# Altium schematic sheet ETH.SchDoc
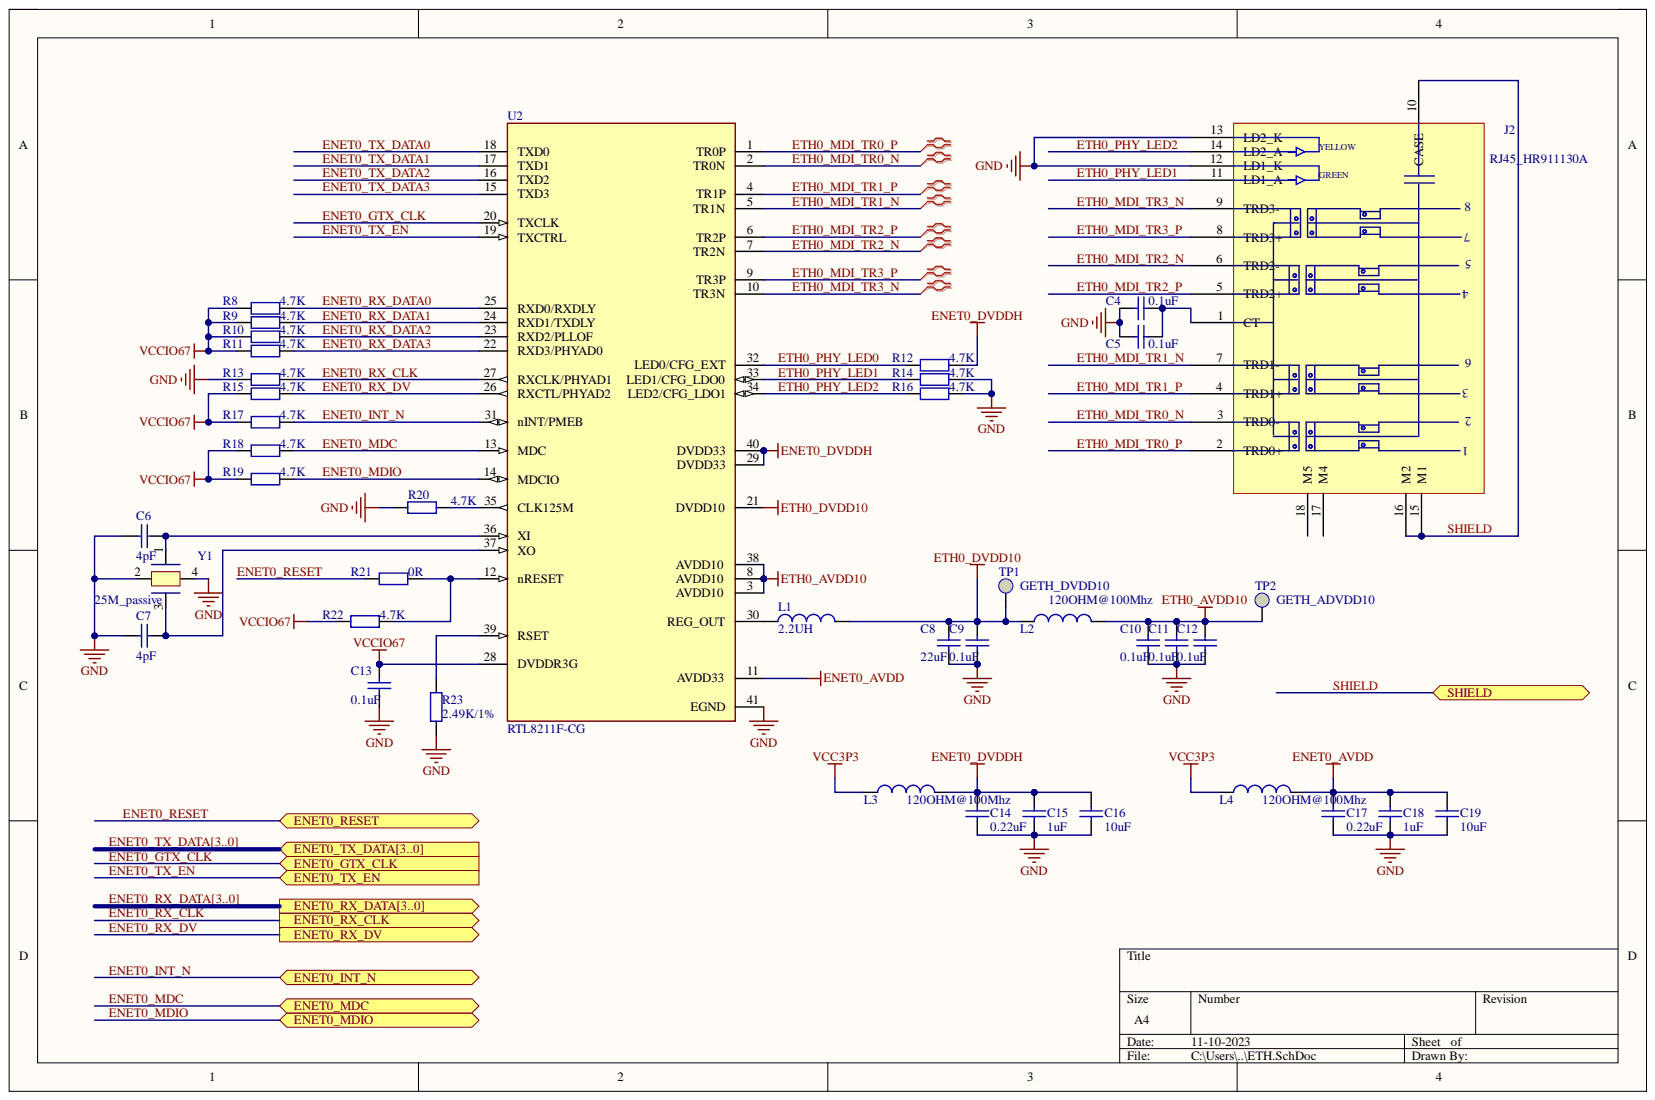
[diagram p0: ETH.SchDoc]

## Parts
C4  0.1uF  [C0402]
C5  0.1uF  [C0402]
C6  4pF  [C0402]
C7  4pF  [C0402]
C8  22uF  [C1206]
C9  0.1uF  [C0402]
C10  0.1uF  [C0402]
C11  0.1uF  [C0402]
C12  0.1uF  [C0402]
C13  0.1uF  [C0402]
C14  0.22uF  [C0402]
C15  1uF  [C0603]
C16  10uF  [C0805]
C17  0.22uF  [C0402]
C18  1uF  [C0603]
C19  10uF  [C0805]
J2  RJ45_HR911130A  [RJ45_HR911130C]
L1  2.2UH  [L0603]
L2  120OHM@100Mhz  [L0603]
L3  120OHM@100Mhz  [L0603]
L4  120OHM@100Mhz  [L0603]
R8  4.7K  [R0402]
R9  4.7K  [R0402]
R10  4.7K  [R0402]
R11  4.7K  [R0402]
R12  4.7K  [R0402]
R13  4.7K  [R0402]
R14  4.7K  [R0402]
R15  4.7K  [R0402]
R16  4.7K  [R0402]
R17  4.7K  [R0402]
R18  4.7K  [R0402]
R19  4.7K  [R0402]
R20  4.7K  [R0402]
R21  0R  [R0402]
R22  4.7K  [R0402]
R23  2.49K/1%  [R0402]
TP1  GETH_DVDD10  [tp32]
TP2  GETH_ADVDD10  [tp32]
U2  RTL8211F-CG  [qfn-40_l5_0-w5_0-p0_4-bl-ep]
Y1  25M_passive  [X4-3225]

## Ports
ENET0_GTX_CLK, ENET0_INT_N, ENET0_MDC, ENET0_MDIO, ENET0_RESET, ENET0_RX_CLK, ENET0_RX_DATA0, ENET0_RX_DATA1, ENET0_RX_DATA2, ENET0_RX_DATA3, ENET0_RX_DATA[3..0], ENET0_RX_DV, ENET0_TX_DATA0, ENET0_TX_DATA1, ENET0_TX_DATA2, ENET0_TX_DATA3, ENET0_TX_DATA[3..0], ENET0_TX_EN, SHIELD

## Nets
ENET0_AVDD: C17.2 C18.2 C19.2 L4.2 U2.11(AVDD33)
ENET0_DVDDH: C14.2 C15.2 C16.2 L3.2 R12.2 U2.29(DVDD33) U2.40(DVDD33)
ETH0_AVDD10: C10.1 C11.1 C12.1 L2.2 TP2.1 U2.3(AVDD10) U2.8(AVDD10) U2.38(AVDD10)
ETH0_DVDD10: C8.1 C9.1 L1.2 L2.1 TP1.1 U2.21(DVDD10)
GND: C4.1 C5.1 C6.1 C7.1 C8.2 C9.2 C10.2 C11.2 C12.2 C13.2 C14.1 C15.1 C16.1 C17.1 C18.1 C19.1 J2.12(LD1_K) J2.13(LD2_K) R13.1 R14.2 R16.2 R20.2 R23.1 U2.41(EGND) Y1.2 Y1.4
VCC3P3: L3.1 L4.1
VCCIO67: C13.1 R8.1 R9.1 R10.1 R11.1 R15.1 R17.1 R18.1 R19.1 R22.1 U2.28(DVDDR3G)
ENET0_GTX_CLK: U2.20(TXCLK) ; port ENET0_GTX_CLK
ENET0_INT_N: R17.2 U2.31(nINT/PMEB) ; port ENET0_INT_N
ENET0_MDC: R18.2 U2.13(MDC) ; port ENET0_MDC
ENET0_MDIO: R19.2 U2.14(MDCIO) ; port ENET0_MDIO
ENET0_RESET: R21.1 ; port ENET0_RESET
ENET0_RX_CLK: R13.2 U2.27(RXCLK/PHYAD1) ; port ENET0_RX_CLK
ENET0_RX_DATA0: R8.2 U2.25(RXD0/RXDLY) ; port ENET0_RX_DATA[3..0]  [also labelled ENET0_RX_DATA]
ENET0_RX_DATA1: R9.2 U2.24(RXD1/TXDLY) ; port ENET0_RX_DATA[3..0]  [also labelled ENET0_RX_DATA]
ENET0_RX_DATA2: R10.2 U2.23(RXD2/PLLOF) ; port ENET0_RX_DATA[3..0]  [also labelled ENET0_RX_DATA]
ENET0_RX_DATA3: R11.2 U2.22(RXD3/PHYAD0) ; port ENET0_RX_DATA[3..0]  [also labelled ENET0_RX_DATA]
ENET0_RX_DV: R15.2 U2.26(RXCTL/PHYAD2) ; port ENET0_RX_DV
ENET0_TX_DATA0: U2.18(TXD0) ; port ENET0_TX_DATA[3..0]  [also labelled ENET0_TX_DATA]
ENET0_TX_DATA1: U2.17(TXD1) ; port ENET0_TX_DATA[3..0]  [also labelled ENET0_TX_DATA]
ENET0_TX_DATA2: U2.16(TXD2) ; port ENET0_TX_DATA[3..0]  [also labelled ENET0_TX_DATA]
ENET0_TX_DATA3: U2.15(TXD3) ; port ENET0_TX_DATA[3..0]  [also labelled ENET0_TX_DATA]
ENET0_TX_EN: U2.19(TXCTRL) ; port ENET0_TX_EN
ETH0_MDI_TR0_N: J2.3(TRD0-) U2.2(TR0N)
ETH0_MDI_TR0_P: J2.2(TRD0+) U2.1(TR0P)
ETH0_MDI_TR1_N: J2.7(TRD1-) U2.5(TR1N)
ETH0_MDI_TR1_P: J2.4(TRD1+) U2.4(TR1P)
ETH0_MDI_TR2_N: J2.6(TRD2-) U2.7(TR2N)
ETH0_MDI_TR2_P: J2.5(TRD2+) U2.6(TR2P)
ETH0_MDI_TR3_N: J2.9(TRD3-) U2.10(TR3N)
ETH0_MDI_TR3_P: J2.8(TRD3+) U2.9(TR3P)
ETH0_PHY_LED0: R12.1 U2.32(LED0/CFG_EXT)
ETH0_PHY_LED1: J2.11(LD1_A) R14.1 U2.33(LED1/CFG_LDO0)
ETH0_PHY_LED2: J2.14(LD2_A) R16.1 U2.34(LED2/CFG_LDO1)
SHIELD: J2.10(CASE) J2.15(M1) J2.16(M2) ; port SHIELD
NetC4_2: C4.2 C5.2 J2.1(CT)
NetC6_2: C6.2 U2.36(XI) Y1.1
NetC7_2: C7.2 U2.37(XO) Y1.3
NetL1_1: L1.1 U2.30(REG_OUT)
NetR20_1: R20.1 U2.35(CLK125M)
NetR21_2: R21.2 R22.2 U2.12(nRESET)
NetR23_2: R23.2 U2.39(RSET)

Not connected: J2 17(M4) 18(M5)

## Library data of the parts
C4-C19 = Cap: Capacitor; Component Kind: Standard; LatestRevisionDate: 17-Jul-2002; LatestRevisionNote: Re-released for DXP Platform.; PackageReference: RAD-0.3; Published: 8-Jun-2000; Signal Integrity: Cap; Simulation: CAP; Publisher: Altium Limited
  C4 C5 C9-C13: Value: 0.1uF
  C6 C7: Library Name: Miscellaneous Devices.IntLib; PackageDescription: Radial Cap, Thru-Hole; 2 Leads; 0.3 in Pin Spacing; Value: 4pF
  C8: Value: 22uF
  C14 C17: Library Name: Miscellaneous Devices.IntLib; PackageDescription: Radial Cap, Thru-Hole; 2 Leads; 0.3 in Pin Spacing; Value: 0.22uF
  C15 C18: Library Name: Miscellaneous Devices.IntLib; PackageDescription: Radial Cap, Thru-Hole; 2 Leads; 0.3 in Pin Spacing; Value: 1uF
  C16 C19: Library Name: Miscellaneous Devices.IntLib; PackageDescription: Radial Cap, Thru-Hole; 2 Leads; 0.3 in Pin Spacing; Value: 10uF
  C4 C5 C8-C13: Library Name: 元件库封装.pcblib
J2 = GE_RJ45_2: Component Kind: Standard; Library Name: Schlib1.SchLib; Value: RJ45_HR911130A
L1 = Inductor: Code_IEC: 1005; Code_JEITA: 0402; Component Kind: Standard; Description: Inductor; LatestRevisionDate: 29-May-2009; LatestRevisionNote: IPC-7351 Footprint Added.; Library Name: Miscellaneous Devices.IntLib; PackageDescription: Chip Inductor; PackageReference: 0402-A; Published: 8-Jun-2000; Value: 2.2UH; Publisher: Altium Limited
L2-L4 = Inductor: Code_IEC: 1005; Value: 100ohm/1.2A; Code_JEITA: 0402; Component Kind: Standard; Description: Inductor; LatestRevisionDate: 29-May-2009; LatestRevisionNote: IPC-7351 Footprint Added.; Library Name: Miscellaneous Devices.IntLib; PackageDescription: Chip Inductor; PackageReference: 0402-A; Published: 8-Jun-2000; Publisher: Altium Limited
R8-R23 = Res2: Resistor; Component Kind: Standard; LatestRevisionDate: 17-Jul-2002; LatestRevisionNote: Re-released for DXP Platform.; PackageReference: AXIAL-0.4; Published: 8-Jun-2000; Library Name: 元件封装库.pcblib; Publisher: Altium Limited
  R8-R20 R22: Value: 4.7K
  R21: Value: 0R
  R23: Value: 2.49K/1%
TP1 TP2 = TestPoint: Component Kind: Standard; Library Name: USB302.Lib
U2 = RTL8211FDI: Component Kind: Standard; Library Name: gjws.SCHLIB
Y1 = OSC: Component Kind: Standard; Library Name: SCHLIB.SchLib
